# Altium schematic sheet Sheet4.SchDoc(Sheet4)
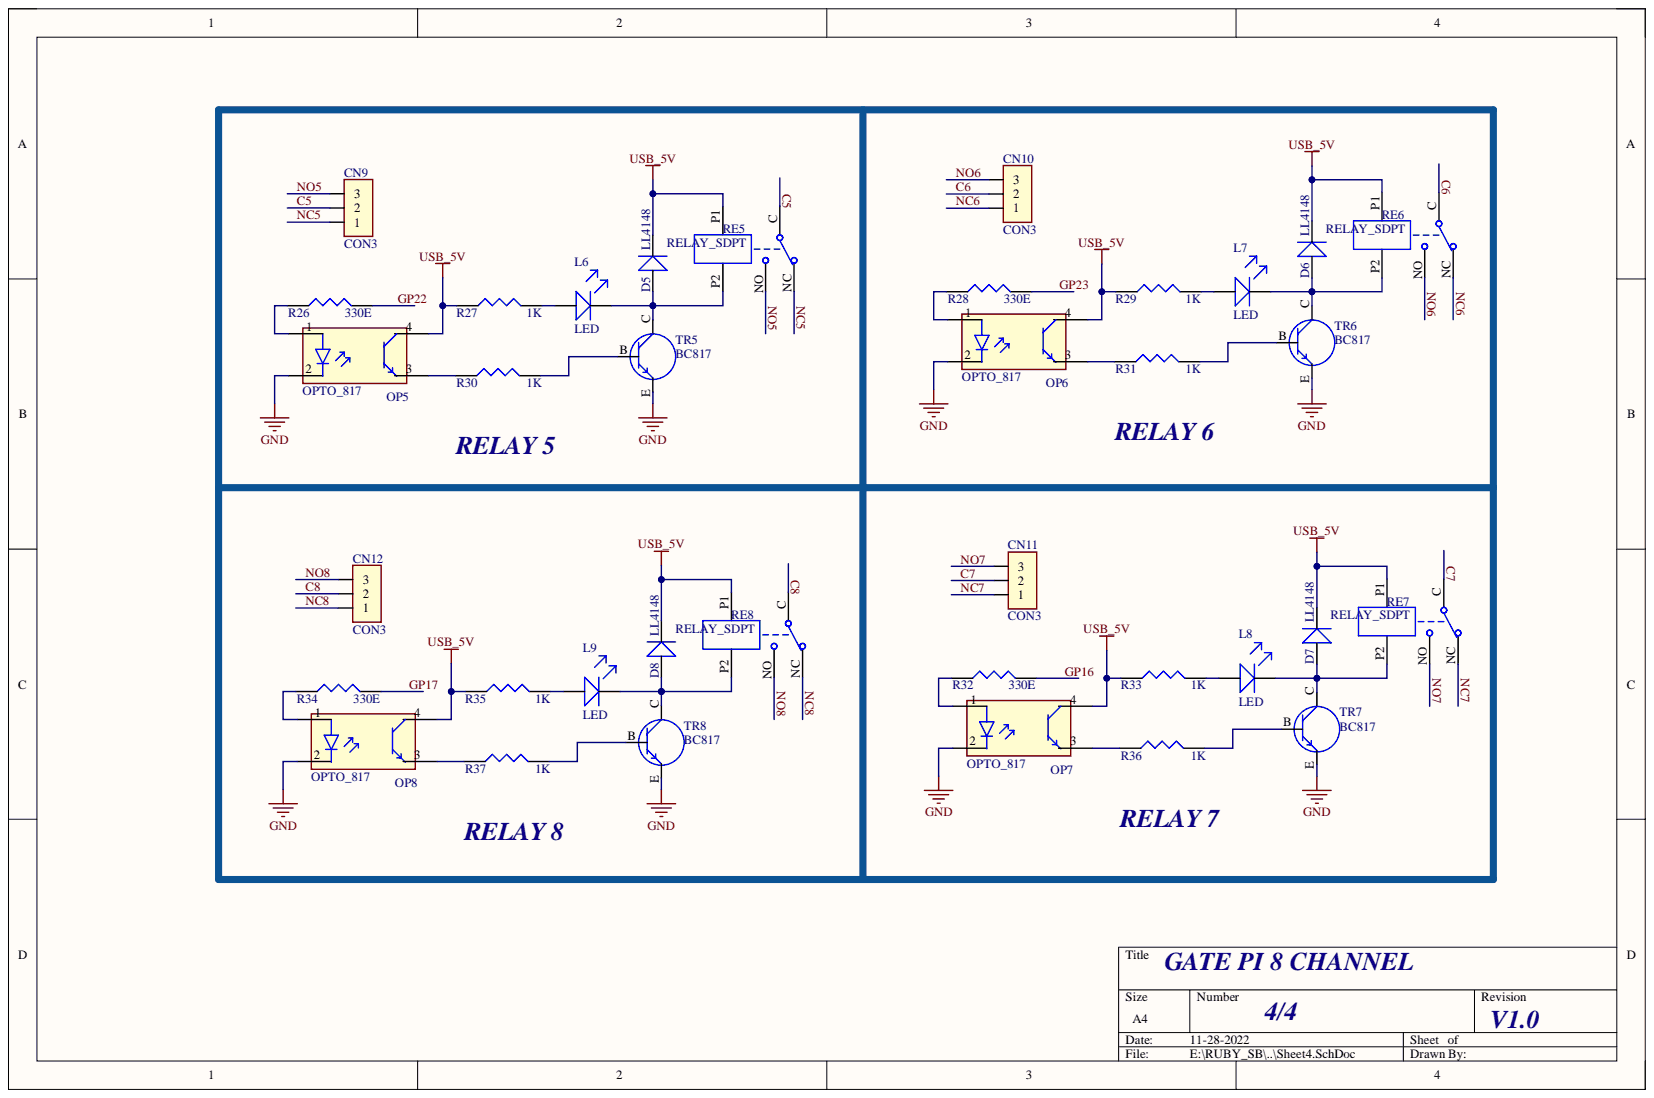
[diagram p0: Sheet4.SchDoc]

## Parts
CN9  CON3  [CON_5MM]
CN10  CON3  [CON_5MM]
CN11  CON3  [CON_5MM]
CN12  CON3  [CON_5MM]
D5  LL4148  [MINI_MELF_SOD80]
D6  LL4148  [MINI_MELF_SOD80]
D7  LL4148  [MINI_MELF_SOD80]
D8  LL4148  [MINI_MELF_SOD80]
L6  LED  [LED_0603]
L7  LED  [LED_0603]
L8  LED  [LED_0603]
L9  LED  [LED_0603]
OP5  OPTO_817  [EL357N_OPTO]
OP6  OPTO_817  [EL357N_OPTO]
OP7  OPTO_817  [EL357N_OPTO]
OP8  OPTO_817  [EL357N_OPTO]
R26  330E  [0603]
R27  1K  [0603]
R28  330E  [0603]
R29  1K  [0603]
R30  1K  [0603]
R31  1K  [0603]
R32  330E  [0603]
R33  1K  [0603]
R34  330E  [0603]
R35  1K  [0603]
R36  1K  [0603]
R37  1K  [0603]
RE5  RELAY_SDPT  [HLS8L-DC5V-S-C RELAY_5V]
RE6  RELAY_SDPT  [HLS8L-DC5V-S-C RELAY_5V]
RE7  RELAY_SDPT  [HLS8L-DC5V-S-C RELAY_5V]
RE8  RELAY_SDPT  [HLS8L-DC5V-S-C RELAY_5V]
TR5  BC817  [547_SOT23]
TR6  BC817  [547_SOT23]
TR7  BC817  [547_SOT23]
TR8  BC817  [547_SOT23]

## Nets
GND: OP5.2 OP6.2 OP7.2 OP8.2 TR5.E TR6.E TR7.E TR8.E
USB_5V: D5.K D6.K D7.K D8.K OP5.4 OP6.4 OP7.4 OP8.4 R27.1 R29.1 R33.1 R35.1 RE5.P1 RE6.P1 RE7.P1 RE8.P1
C5: CN9.2 RE5.C
C6: CN10.2 RE6.C
C7: CN11.2 RE7.C
C8: CN12.2 RE8.C
GP16: R32.2
GP17: R34.2
GP22: R26.2
GP23: R28.2
NC5: CN9.1 RE5.NC
NC6: CN10.1 RE6.NC
NC7: CN11.1 RE7.NC
NC8: CN12.1 RE8.NC
NO5: CN9.3 RE5.NO
NO6: CN10.3 RE6.NO
NO7: CN11.3 RE7.NO
NO8: CN12.3 RE8.NO
NetD5_A: D5.A L6.K RE5.P2 TR5.C
NetD6_A: D6.A L7.K RE6.P2 TR6.C
NetD7_A: D7.A L8.K RE7.P2 TR7.C
NetD8_A: D8.A L9.K RE8.P2 TR8.C
NetL6_A: L6.A R27.2
NetL7_A: L7.A R29.2
NetL8_A: L8.A R33.2
NetL9_A: L9.A R35.2
NetOP5_1: OP5.1 R26.1
NetOP5_3: OP5.3 R30.1
NetOP6_1: OP6.1 R28.1
NetOP6_3: OP6.3 R31.1
NetOP7_1: OP7.1 R32.1
NetOP7_3: OP7.3 R36.1
NetOP8_1: OP8.1 R34.1
NetOP8_3: OP8.3 R37.1
NetR30_2: R30.2 TR5.B
NetR31_2: R31.2 TR6.B
NetR36_2: R36.2 TR7.B
NetR37_2: R37.2 TR8.B

## Library data of the parts
D5-D8 = DIODE: Component Kind: Standard; Library Name: dxp_pasl_lib.IntLib
R26-R37 = RES: Component Kind: Standard; Library Name: dxp_pasl_lib.IntLib
TR5-TR8 = TRANSISTER_NPN: Component Kind: Standard; Library Name: dxp_pasl_lib.IntLib
CN9-CN12 = CON3: Component Kind: Standard; Library Name: dxp_pasl_lib.IntLib
L6-L9 = LED: Component Kind: Standard; Library Name: dxp_pasl_lib.IntLib
OP5-OP8 = OPTO_817: Component Kind: Standard; Library Name: dxp_pasl_lib.IntLib
RE5-RE8 = RELAY_SDPT: Component Kind: Standard; Library Name: dxp_pasl_lib.IntLib
